# Altium schematic sheet PSFB_top_level.SchDoc
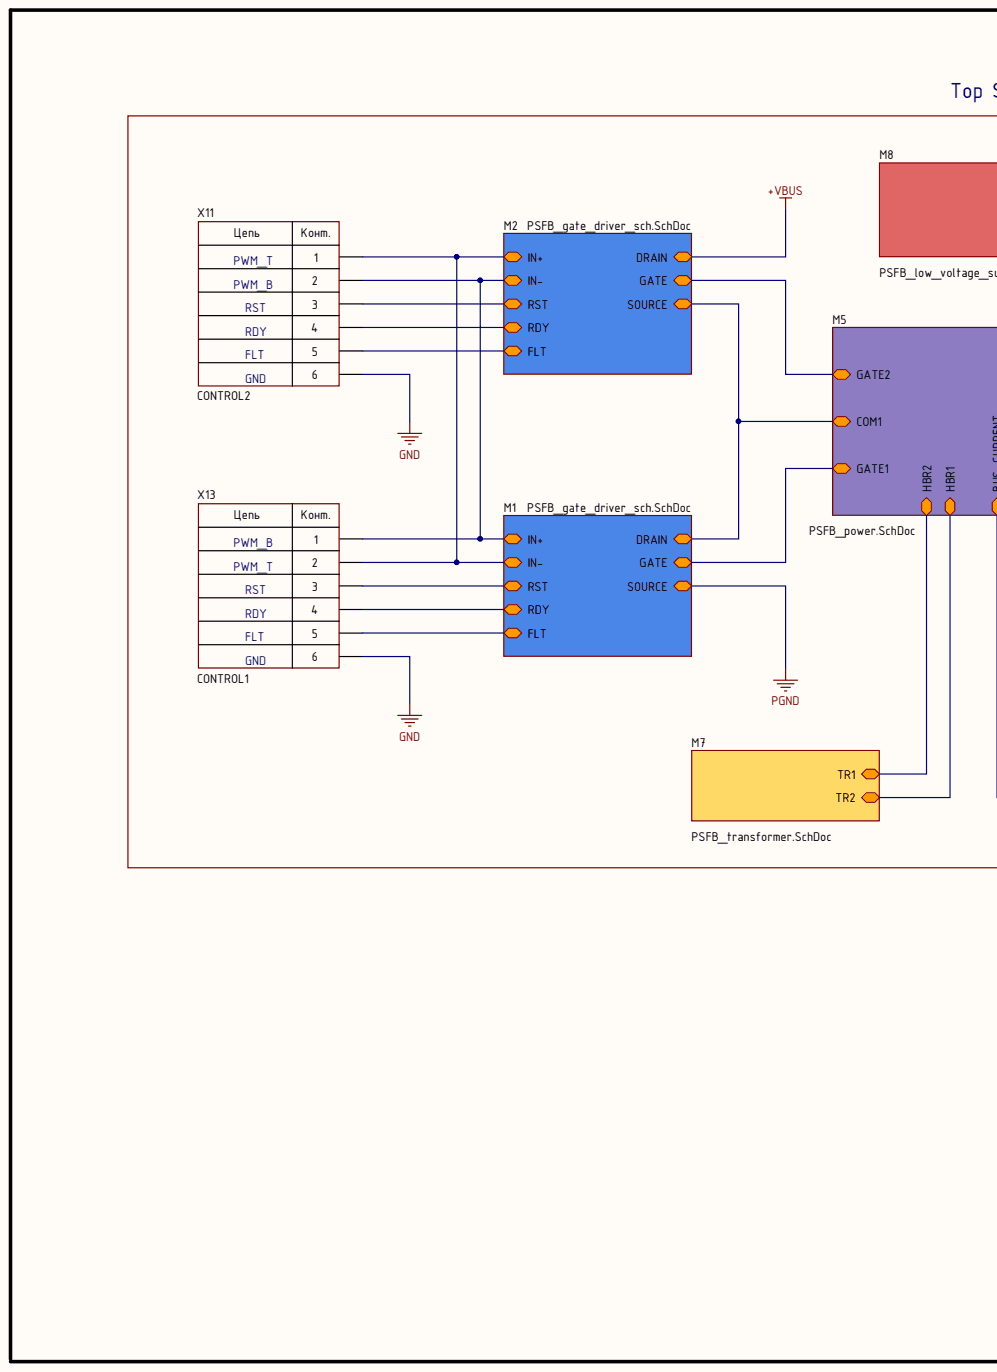
[diagram p0: PSFB_top_level.SchDoc, left]
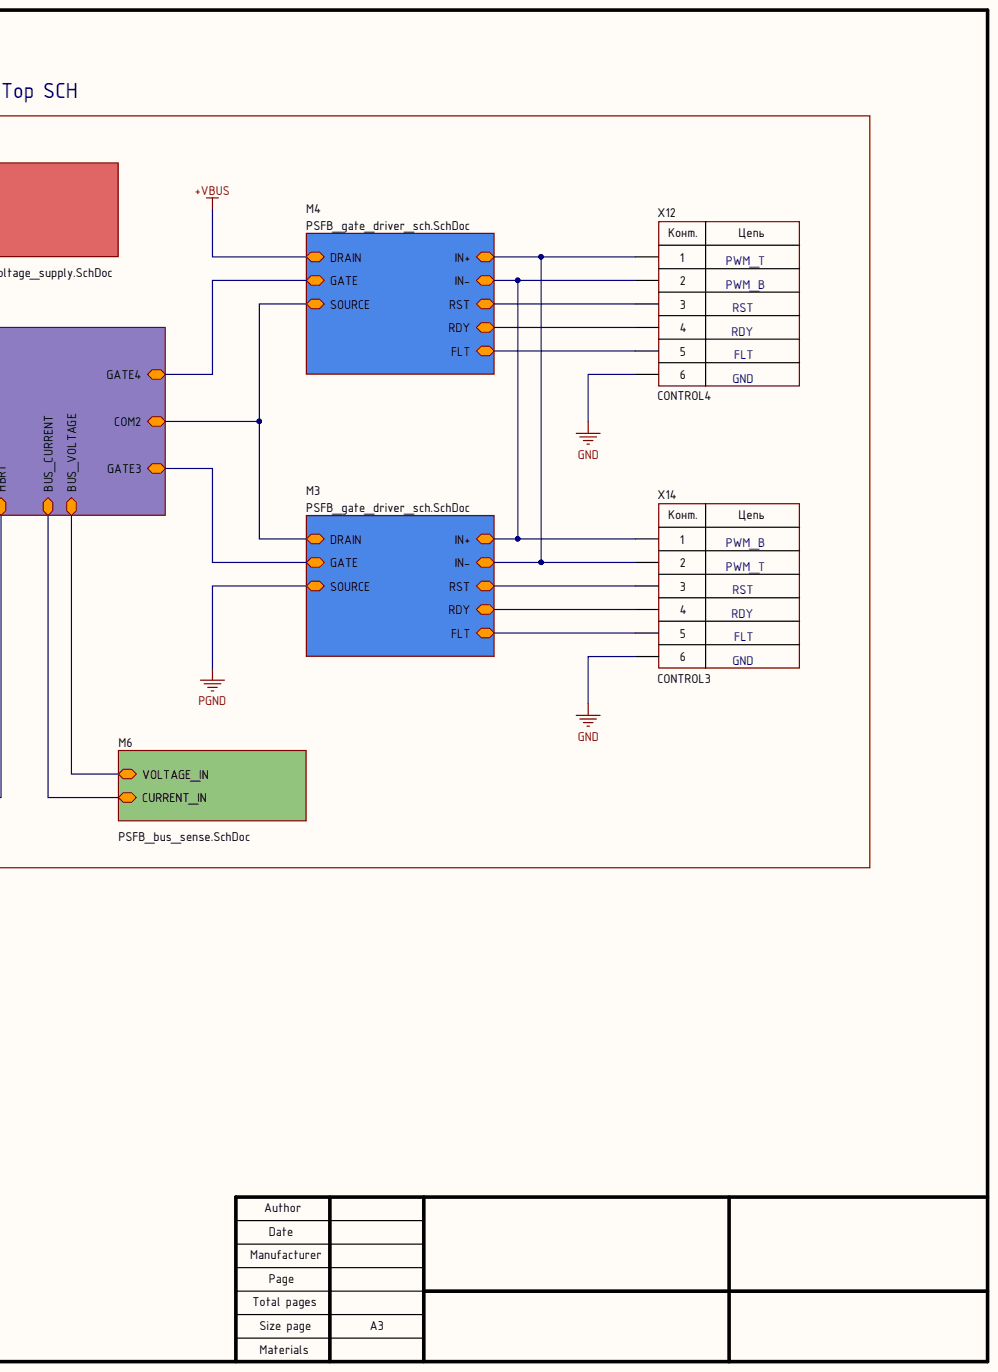
[diagram p1: PSFB_top_level.SchDoc, right]

## Parts
X11  CommentText: CONTROL2  [CON: SM06B-GHS]  (hidden comment: Connector, 6pin, 1.25mm, 50VDC, 1A)
X12  CommentText: CONTROL4  [CON: SM06B-GHS]  (hidden comment: Connector, 6pin, 1.25mm, 50VDC, 1A)
X13  CommentText: CONTROL1  [CON: SM06B-GHS]  (hidden comment: Connector, 6pin, 1.25mm, 50VDC, 1A)
X14  CommentText: CONTROL3  [CON: SM06B-GHS]  (hidden comment: Connector, 6pin, 1.25mm, 50VDC, 1A)

## Nets
GND: X11.6 X12.6 X13.6 X14.6
NetX11_1: X11.1 X13.2
NetX11_2: X11.2 X13.1
NetX11_3: X11.3
NetX11_4: X11.4
NetX11_5: X11.5
NetX12_1: X12.1 X14.2
NetX12_2: X12.2 X14.1
NetX12_3: X12.3
NetX12_4: X12.4
NetX12_5: X12.5
NetX13_3: X13.3
NetX13_4: X13.4
NetX13_5: X13.5
NetX14_3: X14.3
NetX14_4: X14.4
NetX14_5: X14.5
+VBUS:
BUS_CURRENT:
BUS_VOLTAGE:
DRAIN:
GATE:
HBR1:
HBR2:
PGND:

## Library data of the parts
X11-X14 = Connector_SM06B: Connector Header Surface Mount, Right Angle 6 position 0.039" (1.00mm); Manufacturer: JST; PartNumber: SM06B-GHS; Component Kind: Standard; CurrentMax: 1A; HelpURL: https://www.digikey.com/product-detail/en/jst-sales-america-inc/SM06B-GHS-TB-LF-SN/455-1568-1-ND/807836; Library Name: connector.IntLib; Multichannel: 6pin; Pitch: 1.25mm; TypeConnector: Connector; ValueManufacturerPartNumber: Connector, 6pin, 1.25mm, 50VDC, 1A; VoltageMax: 50VDC
